# Altium schematic sheet ble.SchDoc
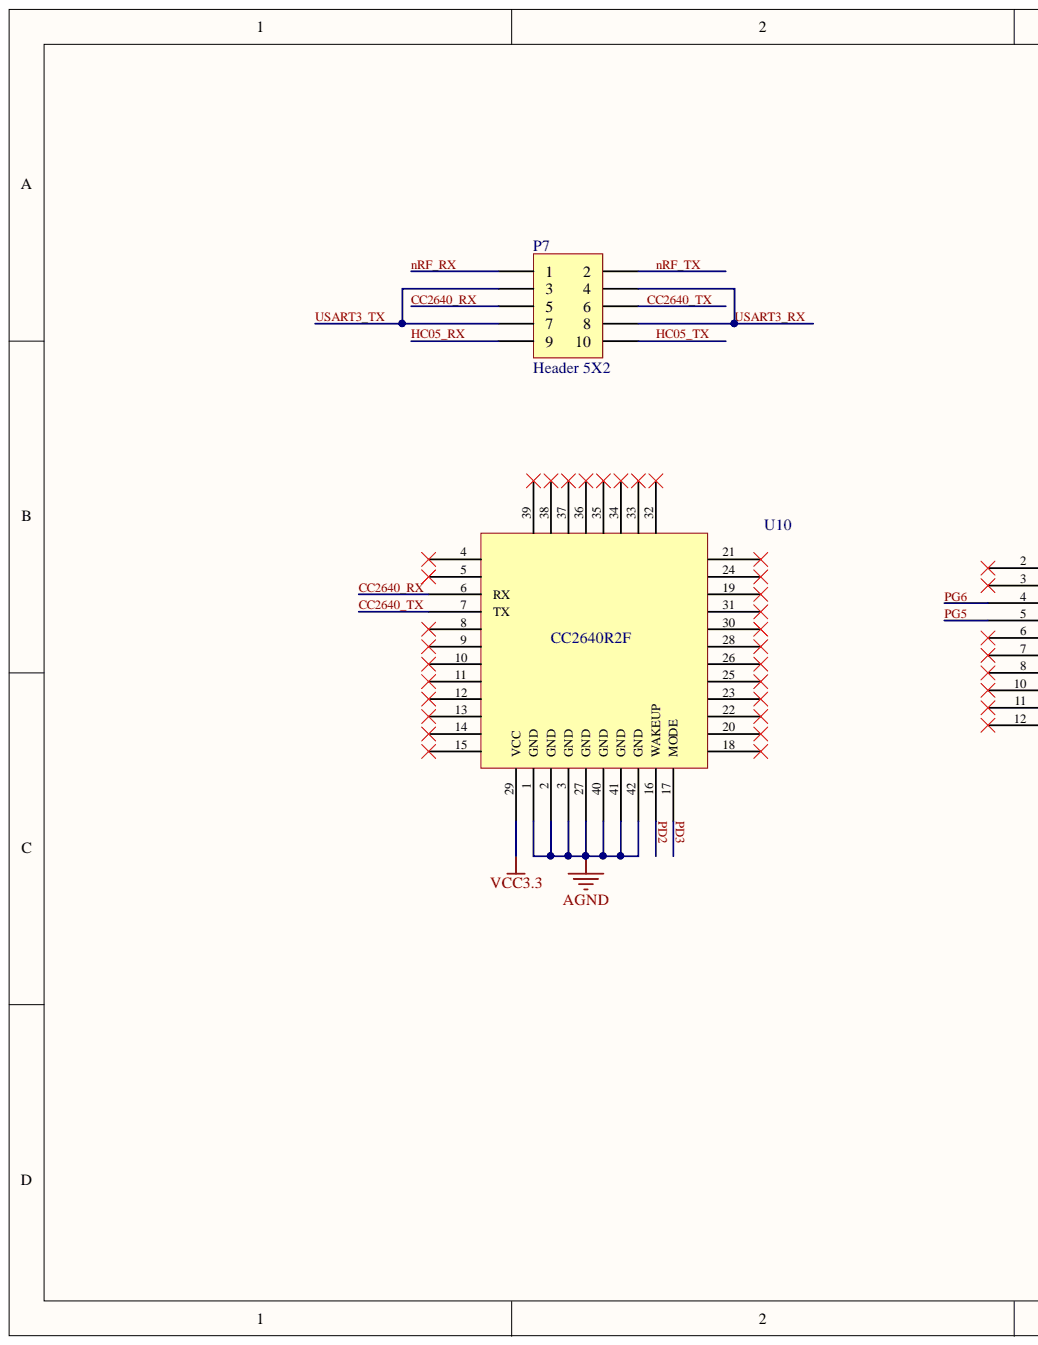
[diagram p0: ble.SchDoc, left]
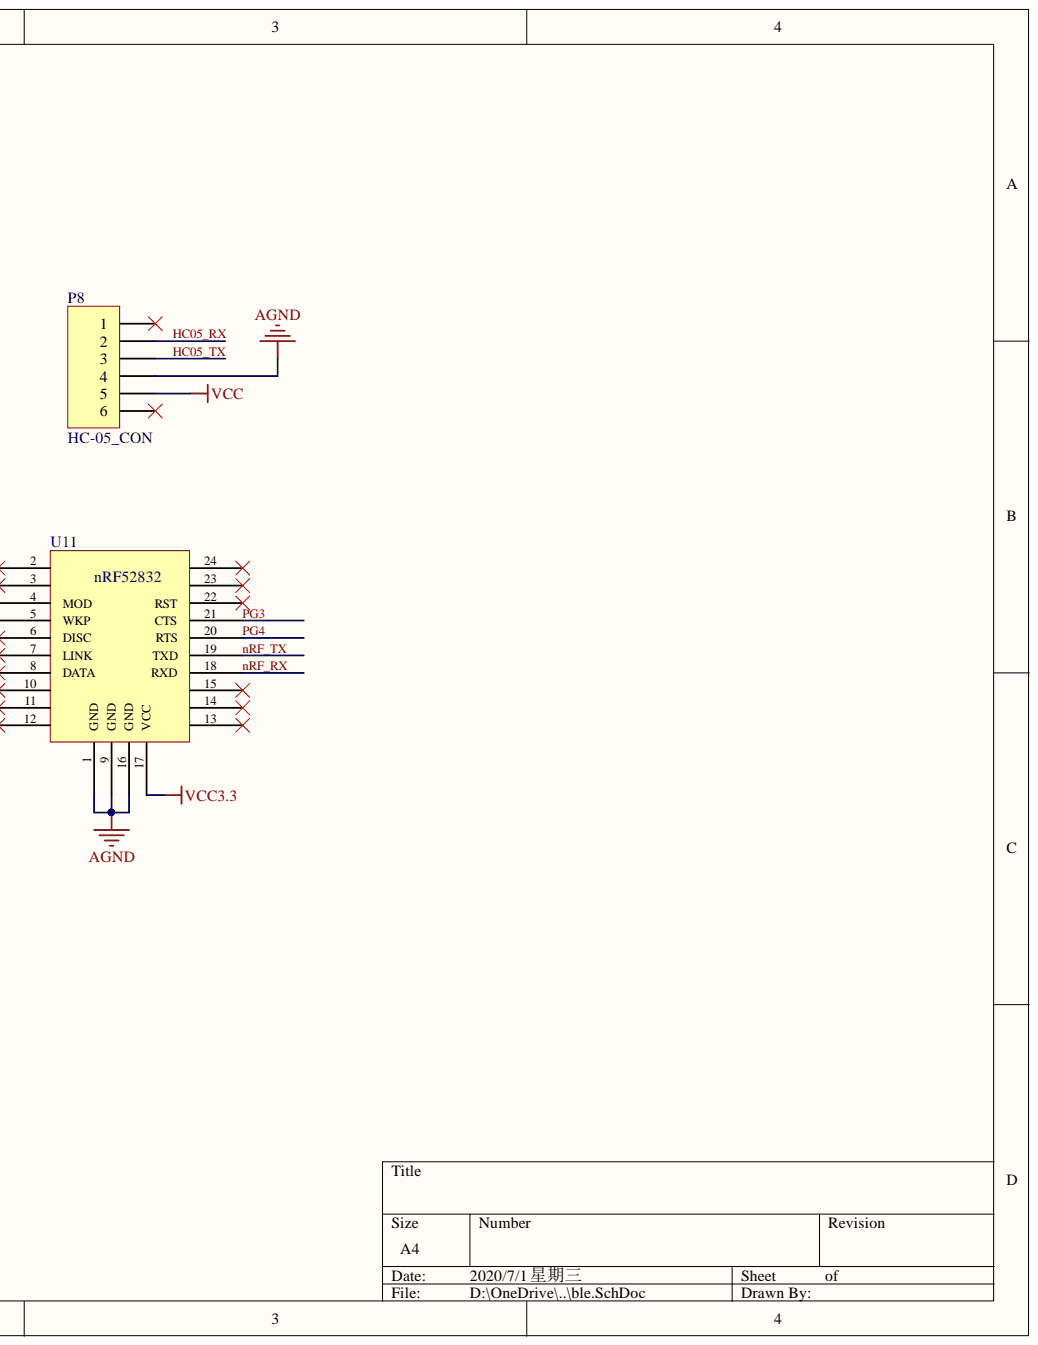
[diagram p1: ble.SchDoc, right]

## Parts
P7  Header 5X2  [HDR2X5]
P8  HC-05_CON  [HDR1X6]
U10  CC2640R2F  [CC2640R2F]
U11  nRF52832  [nRF52832]

## Nets
AGND: P8.4 U10.1(GND) U10.2(GND) U10.3(GND) U10.27(GND) U10.40(GND) U10.41(GND) U10.42(GND) U11.1(GND) U11.9(GND) U11.16(GND)
VCC: P8.5
VCC3.3: U10.29(VCC) U11.17(VCC)
CC2640_RX: P7.5 U10.6(RX)
CC2640_TX: P7.6 U10.7(TX)
HC05_RX: P7.9 P8.2
HC05_TX: P7.10 P8.3
nRF_RX: P7.1 U11.18(RXD)
nRF_TX: P7.2 U11.19(TXD)
PD2: U10.16(WAKEUP)
PD3: U10.17(MODE)
PG3: U11.21(CTS)
PG4: U11.20(RTS)
PG5: U11.5(WKP)
PG6: U11.4(MOD)
USART3_RX: P7.4 P7.8
USART3_TX: P7.3 P7.7

Not connected: P8 1 6; U10 4 5 8 9 10 11 12 13 14 15 18 19 20 21 22 23 24 25 26 28 30 31 32 33 34 35 36 37 38 39; U11 2 3 6(DISC) 7(LINK) 8(DATA) 10 11 12 13 14 15 22(RST) 23 24

## Library data of the parts
P7 = Header 5X2: Header, 5-Pin, Dual row; Component Kind: Standard; LatestRevisionDate: 17-Jul-2002; LatestRevisionNote: Re-released for DXP Platform.; Library Name: Miscellaneous Connectors.IntLib; Signal Integrity: Connector; Publisher: Altium Limited
P8 = Header 6: Header, 6-Pin; Component Kind: Standard; LatestRevisionDate: 17-Jul-2002; LatestRevisionNote: Re-released for DXP Platform.; Library Name: Miscellaneous Connectors.IntLib; Signal Integrity: Connector; Publisher: Altium Limited
U10 = CC2640R2F: Component Kind: Standard; Library Name: EEG.SchLib
U11 = nRF52832: Component Kind: Standard; Library Name: EEG.SchLib
